# Altium schematic sheet Top.SchDoc
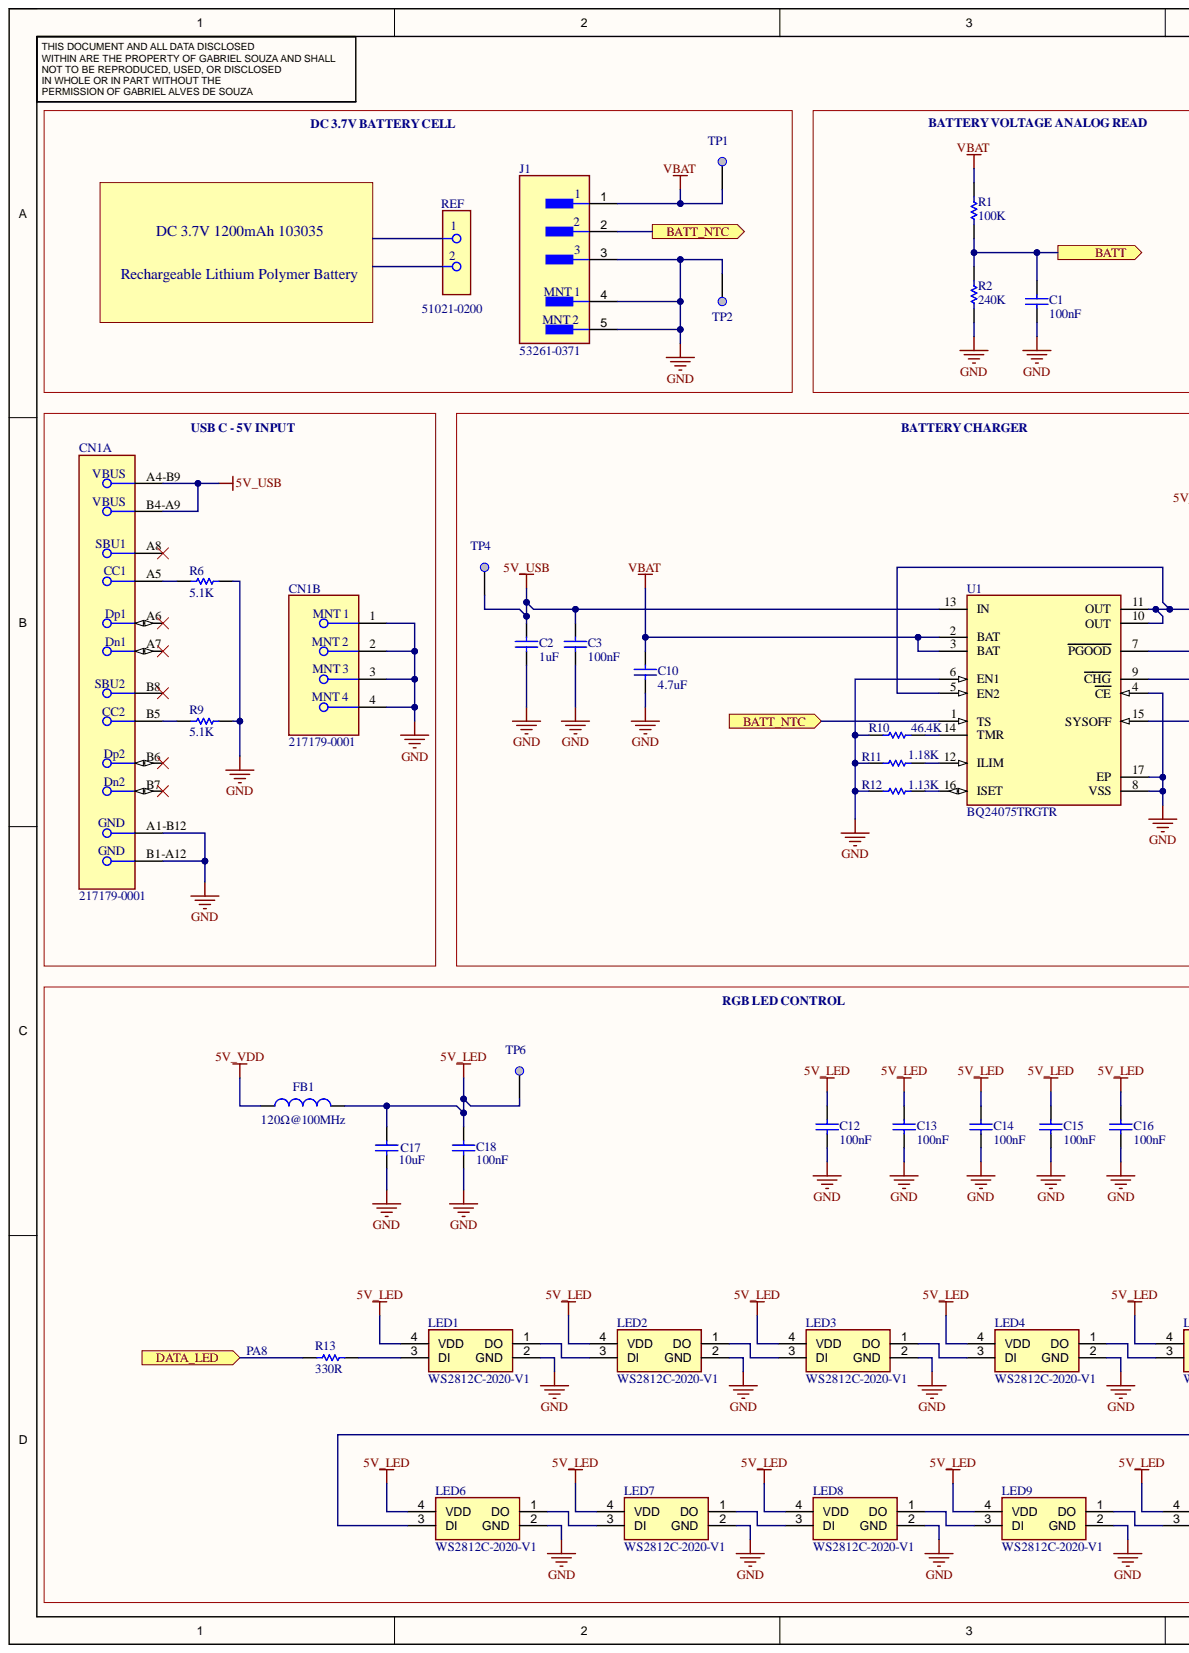
[diagram p0: Top.SchDoc, left]
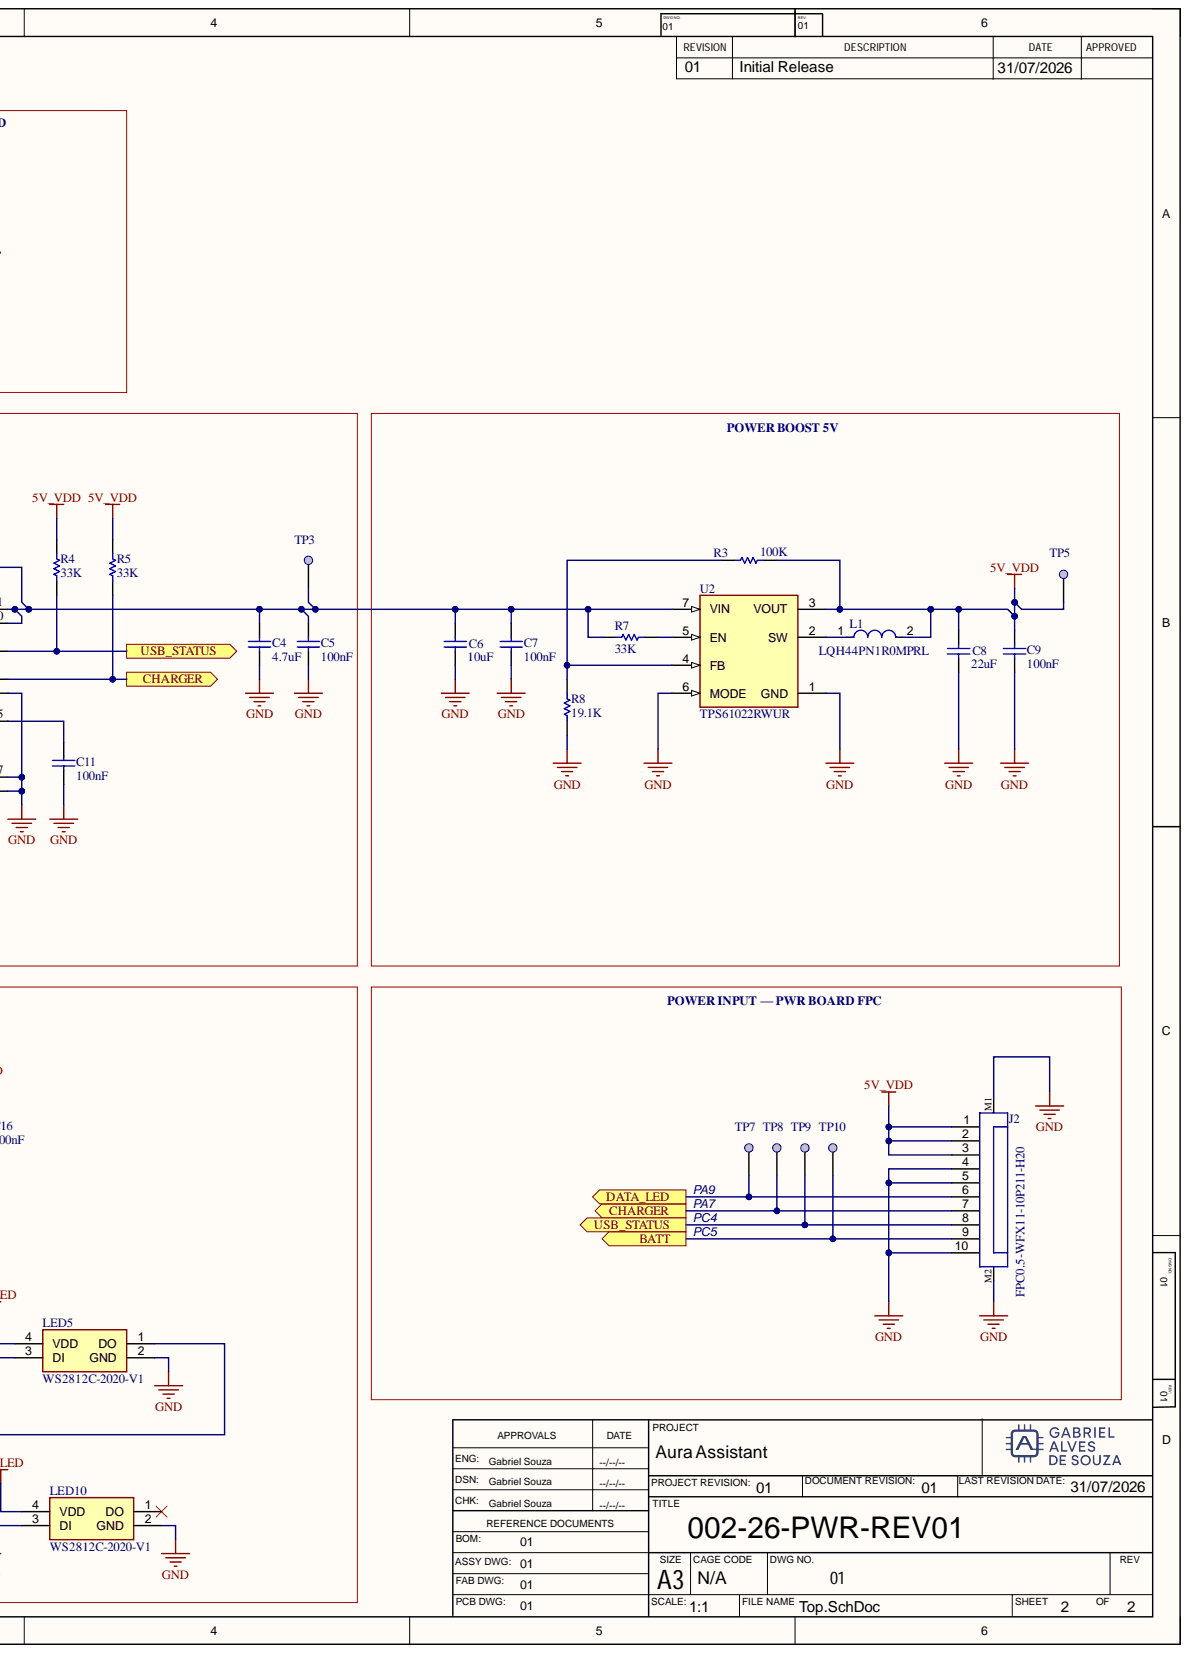
[diagram p1: Top.SchDoc, right]

## Parts
C1  value 100nF  [C_0402_IPC_N]  (hidden comment: Capacitor)
C2  value 1uF  [C_0402_IPC_N]  (hidden comment: Capacitor)
C3  value 100nF  [C_0402_IPC_N]  (hidden comment: Capacitor)
C4  value 4.7uF  [C_0805_IPC_N]  (hidden comment: Capacitor)
C5  value 100nF  [C_0402_IPC_N]  (hidden comment: Capacitor)
C6  value 10uF  [C_0805_IPC_N]  (hidden comment: Capacitor)
C7  value 100nF  [C_0402_IPC_N]  (hidden comment: Capacitor)
C8  value 22uF  [C_1206_IPC_N]  (hidden comment: Capacitor)
C9  value 100nF  [C_0402_IPC_N]  (hidden comment: Capacitor)
C10  value 4.7uF  [C_0805_IPC_N]  (hidden comment: Capacitor)
C11  value 100nF  [C_0402_IPC_N]  (hidden comment: Capacitor)
C12  value 100nF  [C_0402_IPC_N]  (hidden comment: Capacitor)
C13  value 100nF  [C_0402_IPC_N]  (hidden comment: Capacitor)
C14  value 100nF  [C_0402_IPC_N]  (hidden comment: Capacitor)
C15  value 100nF  [C_0402_IPC_N]  (hidden comment: Capacitor)
C16  value 100nF  [C_0402_IPC_N]  (hidden comment: Capacitor)
C17  value 10uF  [C_0805_IPC_N]  (hidden comment: Capacitor)
C18  value 100nF  [C_0402_IPC_N]  (hidden comment: Capacitor)
CN1  217179-0001  [FP-2171790001-MFG]  (parts A,B)
FB1  value 120Ω@100MHz  [L_0603_IPC_N]  (hidden comment: Inductor)
J1  53261-0371  [FP-53261-0371-MFG]
J2  FPC0.5-WFX11-10P211-H20  [687110149022]
L1  LQH44PN1R0MPRL  [FP-LQH44PH_PR-MFG]
LED1  WS2812C-2020-V1  [4684]
LED2  WS2812C-2020-V1  [4684]
LED3  WS2812C-2020-V1  [4684]
LED4  WS2812C-2020-V1  [4684]
LED5  WS2812C-2020-V1  [4684]
LED6  WS2812C-2020-V1  [4684]
LED7  WS2812C-2020-V1  [4684]
LED8  WS2812C-2020-V1  [4684]
LED9  WS2812C-2020-V1  [4684]
LED10  WS2812C-2020-V1  [4684]
R1  value 100K  [R_0402_IPC_N]  (hidden comment: Resistor)
R2  value 240K  [R_0402_IPC_N]  (hidden comment: Resistor)
R3  value 100K  [R_0603_IPC_N]  (hidden comment: Resistor)
R4  value 33K  [R_0402_IPC_N]  (hidden comment: Resistor)
R5  value 33K  [R_0402_IPC_N]  (hidden comment: Resistor)
R6  value 5.1K  [R_0402_IPC_N]  (hidden comment: Resistor)
R7  value 33K  [R_0402_IPC_N]  (hidden comment: Resistor)
R8  value 19.1K  [R_0603_IPC_N]  (hidden comment: Resistor)
R9  value 5.1K  [R_0402_IPC_N]  (hidden comment: Resistor)
R10  value 46.4K  [R_0603_IPC_N]  (hidden comment: Resistor)
R11  value 1.18K  [R_0603_IPC_N]  (hidden comment: Resistor)
R12  value 1.13K  [R_0603_IPC_N]  (hidden comment: Resistor)
R13  value 330R  [R_0603_IPC_N]  (hidden comment: Resistor)
TP1  Test Point  [TP_SMD_2MM]  (value not drawn)  (no BOM)
TP2  Test Point  [TP_SMD_2MM]  (value not drawn)  (no BOM)
TP3  Test Point  [TP_SMD_2MM]  (value not drawn)  (no BOM)
TP4  Test Point  [TP_SMD_2MM]  (value not drawn)  (no BOM)
TP5  Test Point  [TP_SMD_2MM]  (value not drawn)  (no BOM)
TP6  Test Point  [TP_SMD_2MM]  (value not drawn)  (no BOM)
TP7  Test Point  [TP_SMD_2MM]  (value not drawn)  (no BOM)
TP8  Test Point  [TP_SMD_2MM]  (value not drawn)  (no BOM)
TP9  Test Point  [TP_SMD_2MM]  (value not drawn)  (no BOM)
TP10  Test Point  [TP_SMD_2MM]  (value not drawn)  (no BOM)
U1  BQ24075TRGTR  [FP-RGT0016C-IPC_C]
U2  TPS61022RWUR  [FP-RWU0007A-MFG]

## Ports
BATT, BATT_NTC, CHARGER, DATA_LED, USB_STATUS

## Nets
5V_LED: C12.2 C13.2 C14.2 C15.2 C16.2 C17.2 C18.2 FB1.2 LED1.4(VDD) LED2.4(VDD) LED3.4(VDD) LED4.4(VDD) LED5.4(VDD) LED6.4(VDD) LED7.4(VDD) LED8.4(VDD) LED9.4(VDD) LED10.4(VDD) TP6.1
5V_USB: C2.2 C3.2 CN1.A4-B9 CN1.B4-A9 TP4.1 U1.13(IN)
5V_VDD: C8.2 C9.2 FB1.1 J2.1 J2.2 J2.3 L1.2 R3.2 R4.2 R5.2 TP5.1 U2.3(VOUT)
GND: C1.1 C2.1 C3.1 C4.1 C5.1 C6.1 C7.1 C8.1 C9.1 C10.1 C11.1 C12.1 C13.1 C14.1 C15.1 C16.1 C17.1 C18.1 CN1.1 CN1.2 CN1.3 CN1.4 CN1.A1-B12 CN1.B1-A12 J1.3 J1.4 J1.5 J2.4 J2.5 J2.10 J2.M1 J2.M2 LED1.2(GND) LED2.2(GND) LED3.2(GND) LED4.2(GND) LED5.2(GND) LED6.2(GND) LED7.2(GND) LED8.2(GND) LED9.2(GND) LED10.2(GND) R2.1 R6.2 R8.2 R9.2 R10.2 R11.1 R12.1 TP2.1 U1.4(CE) U1.6(EN1) U1.8(VSS) U1.17(EP) U2.1(GND) U2.6(MODE)
VBAT: C10.2 J1.1 R1.2 TP1.1 U1.2(BAT) U1.3(BAT)
BATT: C1.2 J2.9 R1.1 R2.2 TP10.1 ; port BATT
BATT_NTC: J1.2 U1.1(TS) ; port BATT_NTC
CHARGER: J2.7 R5.1 TP8.1 U1.9(CHG) ; port CHARGER
DATA_LED: J2.6 R13.2 TP7.1 ; port DATA_LED
USB_STATUS: J2.8 R4.1 TP9.1 U1.7(PGOOD) ; port USB_STATUS
NetC4_2: C4.2 C5.2 C6.2 C7.2 R7.2 TP3.1 U1.5(EN2) U1.10(OUT) U1.11(OUT) U2.7(VIN)
NetC11_2: C11.2 U1.15(SYSOFF)
NetCN1_A5: CN1.A5 R6.1
NetCN1_B5: CN1.B5 R9.1
NetL1_1: L1.1 U2.2(SW)
NetLED1_1: LED1.1(DO) LED2.3(DI)
NetLED1_3: LED1.3(DI) R13.1
NetLED2_1: LED2.1(DO) LED3.3(DI)
NetLED3_1: LED3.1(DO) LED4.3(DI)
NetLED4_1: LED4.1(DO) LED5.3(DI)
NetLED5_1: LED5.1(DO) LED6.3(DI)
NetLED6_1: LED6.1(DO) LED7.3(DI)
NetLED7_1: LED7.1(DO) LED8.3(DI)
NetLED8_1: LED8.1(DO) LED9.3(DI)
NetLED9_1: LED9.1(DO) LED10.3(DI)
NetR3_1: R3.1 R8.1 U2.4(FB)
NetR7_1: R7.1 U2.5(EN)
NetR10_1: R10.1 U1.14(TMR)
NetR11_2: R11.2 U1.12(ILIM)
NetR12_2: R12.2 U1.16(ISET)

Not connected: CN1 A6 A7 A8 B6 B7 B8; LED10 1(DO)

## Library data of the parts
C1 C3 C5 C7 C9 C11-C16 C18 = CAP: Capacitor, MLCC, 100nF, 16V, X7R, +/-10%, 0402, T=0,5mm; Manufacturer: TDK; Manufacturer_Part_Number: C1005X7R1C104KT000F; Component Kind: Standard; ComponentLink1Description: Datasheet; ComponentLink1URL: https://www.lcsc.com/datasheet/C338032.pdf?spm=wm.sxq.inf.ggs___wm.fly.bg.6.xh&lcsc_vid=TlIKVlcFRVJWVl1WQVFfBlQDRFgPAVVQRFhaBQIFFgQxVlNeRVRbU1RQQ1dWUTtW; Order_Code_LCSC: C338032; Package: 0402; Library Name: Gabriel_Lib.IntLib
C2 = CAP: Capacitor, MLCC, 1uF, 10V, X5R, +/-10%, 0402, T=0,5mm; Manufacturer: FOJAN; Manufacturer_Part_Number: FCC0402X105K100AT; Component Kind: Standard; ComponentLink1Description: Datasheet; ComponentLink1URL: https://www.lcsc.com/datasheet/C5137473.pdf?spm=wm.sxq.inf.ggs___wm.fly.bg.0.xh&lcsc_vid=TlIKVlcFRVJWVl1WQVFfBlQDRFgPAVVQRFhaBQIFFgQxVlNeRVRbU1RQQ1dWUTtW; Order_Code_LCSC: C5137473; Package: 0402; Library Name: Gabriel_Lib.IntLib
C4 C10 = CAP: Capacitor, MLCC, 4.7uF, 10V, X5R, +/-10%, 0805, T=0,5mm; Manufacturer: YAGEO; Manufacturer_Part_Number: CC0805KKX5R6BB475; Component Kind: Standard; ComponentLink1Description: Datasheet; ComponentLink1URL: https://www.lcsc.com/datasheet/C107125.pdf?spm=wm.sxq.inf.ggs___wm.fly.bg.0.xh&lcsc_vid=TlIKVlcFRVJWVl1WQVFfBlQDRFgPAVVQRFhaBQIFFgQxVlNeRVRbU1RQQ1dWUTtW; Order_Code_LCSC: C107125; Package: 0805; Library Name: Gabriel_Lib.IntLib
C6 C17 = CAP: Capacitor, MLCC, 10uF, 16V, X5R, +/-10%, 0805, T=0,5mm; Manufacturer: muRata; Manufacturer_Part_Number: GRM21BR61C106KE15K; Component Kind: Standard; ComponentLink1Description: Datasheet; ComponentLink1URL: https://www.lcsc.com/datasheet/C338032.pdf?spm=wm.sxq.inf.ggs___wm.fly.bg.6.xh&lcsc_vid=TlIKVlcFRVJWVl1WQVFfBlQDRFgPAVVQRFhaBQIFFgQxVlNeRVRbU1RQQ1dWUTtW; Order_Code_LCSC: C408141; Package: 0805; Library Name: Gabriel_Lib.IntLib
C8 = CAP: Capacitor, MLCC, 22uF, 16V, X5R, +/-10%, 1206, T=0,5mm; Manufacturer: muRata; Manufacturer_Part_Number: GRM31CR61C226KE15L; Component Kind: Standard; ComponentLink1Description: Datasheet; ComponentLink1URL: https://www.lcsc.com/datasheet/C338032.pdf?spm=wm.sxq.inf.ggs___wm.fly.bg.6.xh&lcsc_vid=TlIKVlcFRVJWVl1WQVFfBlQDRFgPAVVQRFhaBQIFFgQxVlNeRVRbU1RQQ1dWUTtW; Order_Code_LCSC: C77088; Package: 1206; Library Name: Gabriel_Lib.IntLib
CN1 = CMP-04767-000028-2: CONN RCP USB2.0 TYP C 24P SMD RA; NexarPartId: 117190526; Current Rating: 1.25A; Gender: Receptacle; Number of Contacts: 16; Voltage Rating: 30V; Component Kind: Standard; Contact Material: Gold, Nickel; Height: 3.36mm; Library Name: Altium Content Vault; Max Operating Temperature: 85°C; Min Operating Temperature: -40°C; Order_Code_LCSC: C3197684; USB Standard: USB 2.0
FB1 = Inductor: FERRITE BEAD 120Ω 0603 1LN; Manufacturer: muRata; Manufacturer_Part_Number: BLM18PG121SN1D; Component Kind: Standard; ComponentLink1URL: http://tunmcdsvn.tun.st.com/Component_Documentation_Library/Trunk/Inductor/MURATA_DFE201612P_Series/; Order_Code_LCSC: C14709; Part_Type: Inductor; Library Name: Gabriel_Lib.IntLib
J1 = CMP-04776-000071-1: CONN HEADER SMD R/A 3POS 1.25MM; Manufacturer: Molex; Conn Gender: Male; Conn Orientation: Right Angle; Current Rating: 1A; Number Of Contacts: 3; Voltage Rating: 125V; Ambient Temperature Range High: +105°C; Ambient Temperature Range Low: -40°C; Automotive: No; Category: Conn; Component Kind: Standard; ComponentLink2Description: Datasheet; ComponentLink2URL: https://www.molex.com/webdocs/datasheets/pdf/en-us/0532610371_PCB_HEADERS.pdf; Contact Material: Tin,Nickel; Device Class L1: Connectors; Device Class L2: Headers and Wire Housings; Device Class L3: unset; Digikey Description: CONN HEADER SMD R/A 3POS 1.25MM; Footprint Url: https://www.molex.com/pdm_docs/sd/532610371_sd.pdf; Height: 3.6mm; Lead Free: Yes; Library Name: Altium Content Vault; Manufacturer Part Number: 53261-0371; Mouser Description: 1.25mm (.049") Pitch PicoBlade Header, Surface Mount, Right Angle, Lead-free, 3 Circuits; Number Of Rows: 1; Octopart: https://octopart.com/53261-0371-molex-655314; Order_Code_LCSC: C293630; Package: 0; Pitch: 1.25mm; RoHS: TRUE; Temperature: -40°C to +105°C; Temperature Range High: +105°C; Temperature Range Low: -40°C
J2 = CMP-1502-01625-3: CONN FFC FPC 10POS 0.5mm BOTTOM CNT SMD R/A; Manufacturer: HMTCONN; Number of Contacts: 10; Component Kind: Standard; Library Name: Altium Content Vault; Manufacturer_Part_Number: FPC0.5-WFX11-10P211-H20; Order_Code_LCSC: C42407926
L1 = CMP-06042-017094-2: FIXED IND 1.0UH 4300MA NONAUTO; Component Kind: Standard; Library Name: Altium Content Vault; Order_Code_LCSC: C5752187
LED1-LED10 = 4684: LED; Manufacturer_Name: Worldsemi; Arrow Part Number: 4684; Arrow Price/Stock: https://www.arrow.com/en/products/4684/adafruit-industries?utm_currency=USD&region=nac; Component Kind: Standard; Datasheet Link: https://cdn-shop.adafruit.com/product-files/4684/4684_WS2812B-2020_V1.3_EN.pdf; Height: 0.84mm; Manufacturer_Part_Number: WS2812C-2020-V1; Mouser Part Number: 485-4684; Mouser Price/Stock: https://www.mouser.co.uk/ProductDetail/Adafruit/4684?qs=DPoM0jnrROWIv9%2FMCIm5vw%3D%3D; Order_Code_LCSC: C2976072
R1 = RES: Resistor, 100K, 0402, 0.1%, 62.5mW; Manufacturer: YAGEO; Manufacturer_Part_Number: RT0402BRD07100KL; Component Kind: Standard; ComponentLink1Description: Datasheet; Order_Code_LCSC: C852472; Package: 0402; Part_Type: Resistor; Library Name: Gabriel_Lib.IntLib
R2 = RES: Resistor, 240K, 0402, 0.1%, 62.5mW; Manufacturer: UNI-ROYAL; Manufacturer_Part_Number: TC0250B2403TCC; Component Kind: Standard; ComponentLink1Description: Datasheet; Order_Code_LCSC: C7431039; Package: 0402; Part_Type: Resistor; Library Name: Gabriel_Lib.IntLib
R3 = RES: Resistor,100K, 0603, 0.1%, 100mW; Manufacturer: YAGEO; Manufacturer_Part_Number: RT0603BRD07100KL; Component Kind: Standard; ComponentLink1Description: Datasheet; Order_Code_LCSC: C122538; Package: 0603; Part_Type: Resistor; Library Name: Gabriel_Lib.IntLib
R4 R5 R7 = RES: Resistor, 33K, 0402, 0.1%, 62.5mW; Manufacturer: YAGEO; Manufacturer_Part_Number: RT0402BRD0733KL; Component Kind: Standard; ComponentLink1Description: Datasheet; Order_Code_LCSC: C728559; Package: 0402; Part_Type: Resistor; Library Name: Gabriel_Lib.IntLib
R6 R9 = RES: Resistor, 5.1K, 0402, 1%, 62.5mW; Manufacturer: YAGEO; Manufacturer_Part_Number: RT0402BRD0733KL; Component Kind: Standard; ComponentLink1Description: Datasheet; Order_Code_LCSC: C2906874; Package: 0402; Part_Type: Resistor; Library Name: Gabriel_Lib.IntLib
R8 = RES: Resistor, 19.1K, 0603, 0.1%, 100mW; Manufacturer: VISHAY; Manufacturer_Part_Number: TNPW060319K1BEEN; Component Kind: Standard; ComponentLink1Description: Datasheet; Order_Code_LCSC: C3009193; Package: 0603; Part_Type: Resistor; Library Name: Gabriel_Lib.IntLib
R10 = RES: Resistor, 46.4K, 0603, 0.1%, 0.1W; Manufacturer: YAGEO; Manufacturer_Part_Number: RT0603BRD0746K4L; Component Kind: Standard; ComponentLink1Description: Datasheet; Order_Code_LCSC: C861420; Package: 0603; Part_Type: Resistor; Library Name: Gabriel_Lib.IntLib
R11 = RES: Resistor, 1.18K, 0603, 1%, 100mW; Manufacturer: UNI-ROYAL; Manufacturer_Part_Number: 0603WAF1181T5E; Component Kind: Standard; ComponentLink1Description: Datasheet; Order_Code_LCSC: C22763; Package: 0603; Part_Type: Resistor; Library Name: Gabriel_Lib.IntLib
R12 = RES: Resistor, 1.13K, 0603, 0.1%, 100mW; Manufacturer: UNI-ROYAL; Manufacturer_Part_Number: 0603WAF1131T5E; Component Kind: Standard; ComponentLink1Description: Datasheet; Order_Code_LCSC: C22833; Package: 0603; Part_Type: Resistor; Library Name: Gabriel_Lib.IntLib
R13 = RES: Resistor, 330R, 0603, 0.1%, 0.1W; Manufacturer: Viking; Manufacturer_Part_Number: AR03BTCX3300; Component Kind: Standard; ComponentLink1Description: Datasheet; Order_Code_LCSC: C2984350; Package: 0603; Part_Type: Resistor; Library Name: Gabriel_Lib.IntLib
TP1-TP10 = MCD-00177: Test Point, SMD, 2mm; Part_Preference: Recommended; Part_Type: Misc; Component_Country_Of_Origin: Unknown; Database Table Name: MCD_PARTS$; ID: 2690; ShowFields: YES; ComponentLink1URL: http://tunmcdsvn.tun.st.com/Component_Documentation_Library/Trunk/Misc; Internal_Part_Number: MCD-00177; Library Name: MCD_PARTS_database.DbLib; Manufacturer: N/A; Manufacturer_Part_Number: N/A; Order_Code_Digikey: N/A; Order_Code_Farnell: N/A; Order_Code_RS: N/A; Status: Released; Value: N/A
U1 = CMP-0321-00266-3: IC LI-ION CHARGE/PWR MGMT 16QFN; Max Power Dissipation: 2.3W; Max Supply Voltage: 6.4V; Min Input Voltage: 4.3V; Min Supply Voltage: 4.35V; Number of Cells: 1; Operating Supply Current: 1.5mA; Output Current: 1.5A; Output Voltage: 4.2V; Case/Package: VQFN EP; Component Kind: Standard; Contact Plating: Gold; Lead Free: Lead Free; Library Name: Altium Content Vault; Max Operating Temperature: 85°C; Min Operating Temperature: -40°C; Number of Pins: 16; Order_Code_LCSC: C544783; Package Quantity: 1; Packaging: Tape and Reel; Radiation Hardening: No; RoHS Compliant: Yes; Series: -
U2 = CMP-04918-000029-1: POWER MANAGEMENT; Manufacturer: Texas Instruments; Max Duty Cycle: 97%; Max Junction Temp: +125°C; Max Supply Voltage: 5.5V; Min Supply Voltage: 0.5V; Nominal Supply Current: 27uA; Number Of Outputs: 1; Output Current: 8A; Output Type: AdjustableProgrammable; Output Voltage: 2.2-5.5V; Standoff Height: 0mm; Switching Frequency: 600-1000kHz; Switching Topology: Boost; Automotive: No; Category: IC; Component Kind: Standard; ComponentLink2Description: Datasheet; ComponentLink2URL: http://www.ti.com/lit/ds/symlink/tps61022.pdf; Device Class L1: Integrated Circuits (ICs); Device Class L2: Power Management ICs; Device Class L3: Voltage Regulators - Switching; Digikey Description: POWER MANAGEMENT; Height: 1mm; Lead Free: Yes; Library Name: Altium Content Vault; Manufacturer Part Number: TPS61022RWUR; Mouser Description: 8-A boost converter with 0.5-V ultra-low input voltage 7-VQFN-HR -40 to 125; Octopart: https://octopart.com/tps61022rwur-texas+instruments-100045592; Order_Code_LCSC: C915088; Package: RWU0007A; RoHS: TRUE; Temperature: -40°C to +125°C; Temperature Range High: +125°C; Temperature Range Low: -40°C
